# Altium schematic sheet buttonSchematic.SchDoc(buttonSchematic)
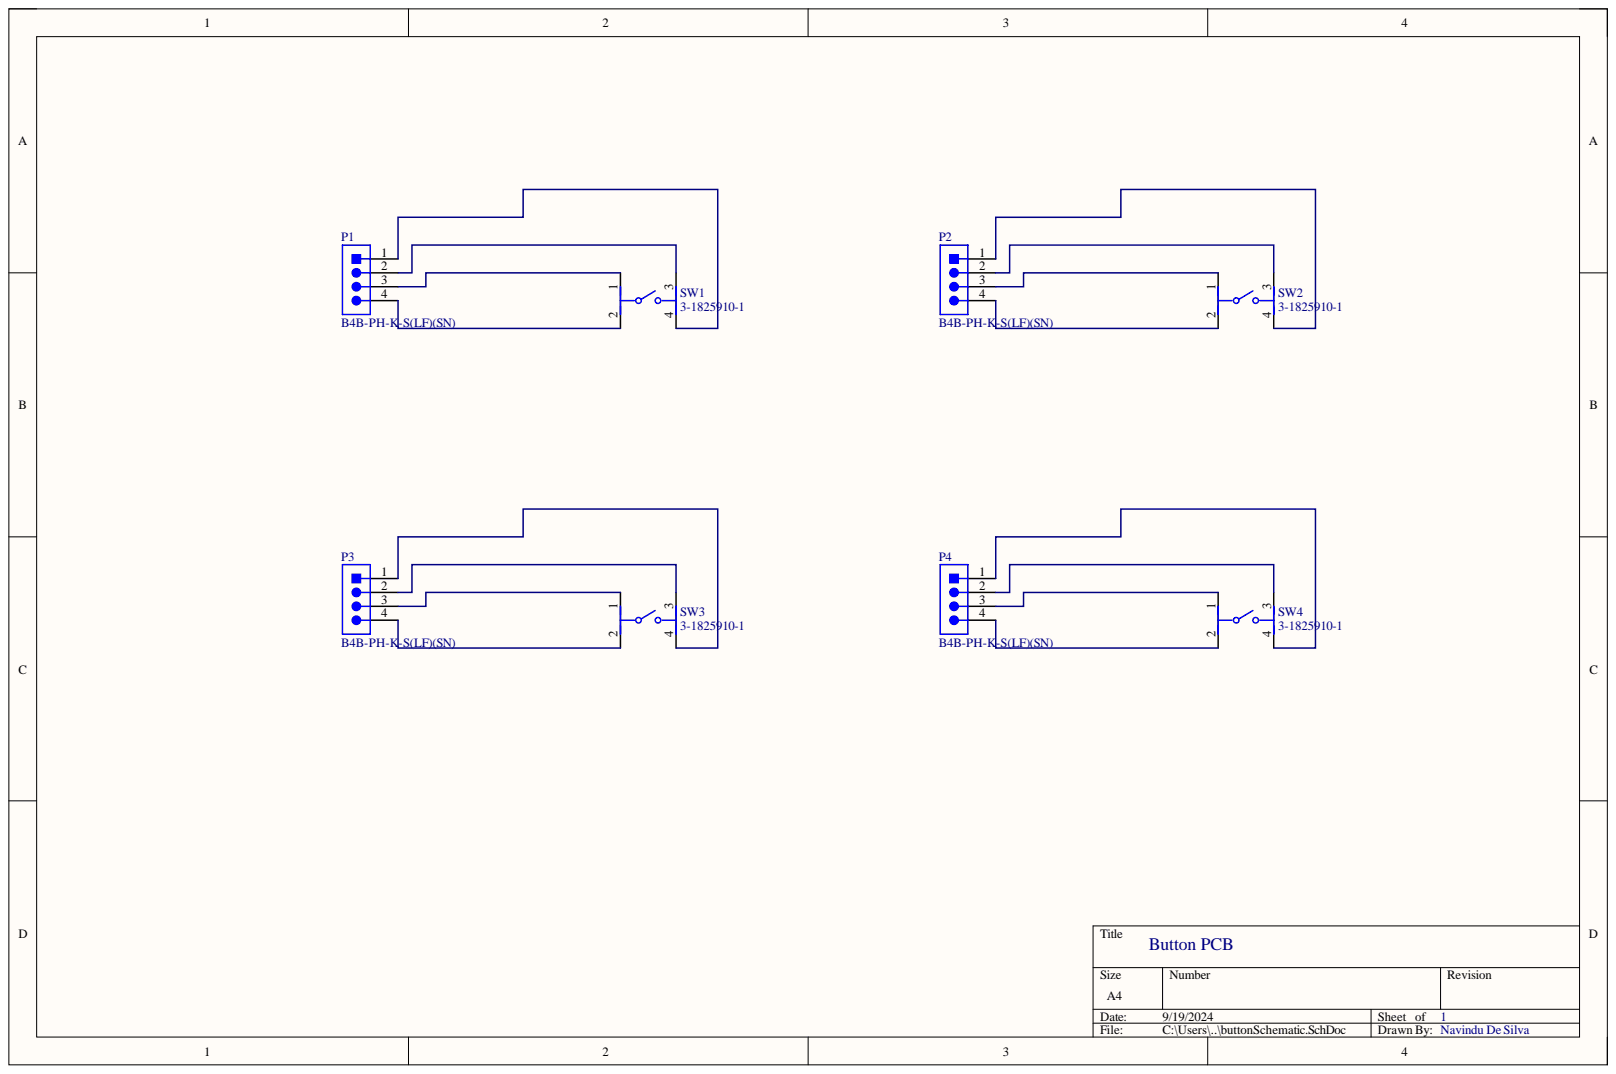
[diagram p0: buttonSchematic.SchDoc]

## Parts
P1  B4B-PH-K-S(LF)(SN)  [JST-B4B-PH-K-S_V]
P2  B4B-PH-K-S(LF)(SN)  [JST-B4B-PH-K-S_V]
P3  B4B-PH-K-S(LF)(SN)  [JST-B4B-PH-K-S_V]
P4  B4B-PH-K-S(LF)(SN)  [JST-B4B-PH-K-S_V]
SW1  3-1825910-1  [FP-3-1825910-1-MFG]
SW2  3-1825910-1  [FP-3-1825910-1-MFG]
SW3  3-1825910-1  [FP-3-1825910-1-MFG]
SW4  3-1825910-1  [FP-3-1825910-1-MFG]

## Nets
NetP1_1: P1.1 SW1.4
NetP1_2: P1.2 SW1.3
NetP1_3: P1.3 SW1.1
NetP1_4: P1.4 SW1.2
NetP2_1: P2.1 SW2.4
NetP2_2: P2.2 SW2.3
NetP2_3: P2.3 SW2.1
NetP2_4: P2.4 SW2.2
NetP3_1: P3.1 SW3.4
NetP3_2: P3.2 SW3.3
NetP3_3: P3.3 SW3.1
NetP3_4: P3.4 SW3.2
NetP4_1: P4.1 SW4.4
NetP4_2: P4.2 SW4.3
NetP4_3: P4.3 SW4.1
NetP4_4: P4.4 SW4.2

## Library data of the parts
P1-P4 = CMP-2000-05165-1: Male Header, Pitch 2 mm, 1 x 4 Position, Height 6 mm, Tail Length 3.4 mm, -25 to 85 degC, RoHS, Bulk; Manufacturer: JST; No of Positions: 4; Pitch: 2mm; Style/Orientation: StraightHeader/Plug; Component Kind: Standard; Datasheet URL: http://datasheet.octopart.com/B4B-PH-K-S%28LF%29%28SN%29-JST-datasheet-10558886.pdf; Datasheet Version: Apr-09; Library Name: Altium Content Vault; Manufacturer URL: http://www.jst.com/home2.html; Termination: Crimp; Type: ShroudedHeader
SW1-SW4 = CMP-03407-000001-1: SWITCH TACTILE SPST-NO 0.05A 24V; Manufacturer: TE Connectivity; Contact Current Rating: 50mA; Contact Resistance: 100mΩ; Electromechanical Life: 100000Cycles; Operating Force: 160gf; Automotive: No; Category: Switch; Component Kind: Standard; ComponentLink2Description: Datasheet; ComponentLink2URL: https://www.te.com/commerce/DocumentDelivery/DDEController?Action=srchrtrv&DocNm=1825910&DocType=Customer+Drawing&DocLang=English; Device Class L1: Electromechanical; Device Class L2: Switches; Device Class L3: Tactile Switches; Digikey Description: SWITCH TACTILE SPST-NO 0.05A 24V; Height: 17.3mm; Lead Free: Yes; Library Name: Altium Content Vault; Manufacturer Part Number: 3-1825910-1; Mount: Through Hole; Octopart: https://octopart.com/3-1825910-1-te+connectivity-46506874; Package: 0; RoHS: TRUE; Temperature: -35°C to +85°C; Temperature Range High: +85°C; Temperature Range Low: -35°C; Throw Configuration: SPST-NO
